# Altium schematic sheet MCU.SchDoc
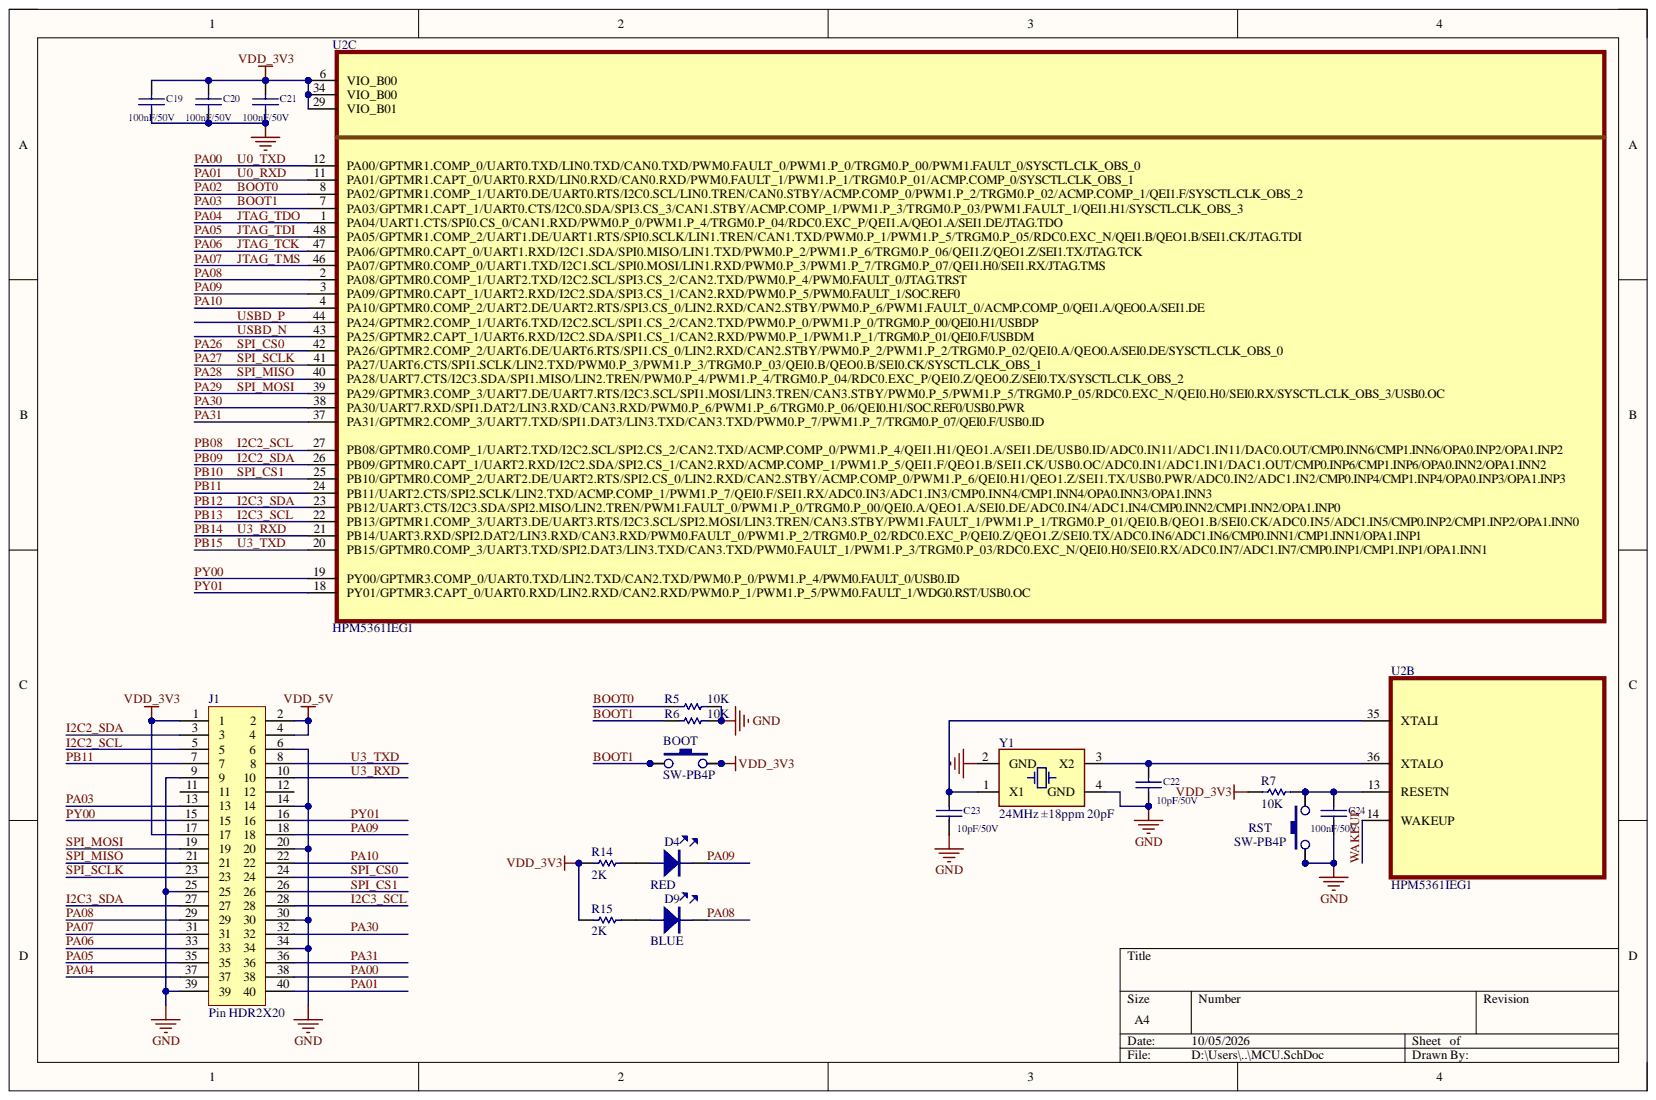
[diagram p0: MCU.SchDoc]

## Parts
BOOT  SW-PB4P  [SMD,3.3x4.2mm]
C19  100nF/50V  [C0402]
C20  100nF/50V  [C0402]
C21  100nF/50V  [C0402]
C22  10pF/50V  [C0402]
C23  10pF/50V  [C0402]
C24  100nF/50V  [C0402]
D4  RED  [LED0402 RED]
D9  BLUE  [LED0402 BLUE]
J1  Pin HDR2X20  [Pin HDR2X20/2.54mm-S]
R5  10K  [R0402]
R6  10K  [R0402]
R7  10K  [R0402]
R14  2K  [R0402]
R15  2K  [R0402]
RST  SW-PB4P  [SMD,3.3x4.2mm]
U2  HPM5361IEG1  [QFN48 6*6]  (parts B,C)
Y1  24MHz ±18ppm 20pF  [OSC 2016-4P]

## Nets
GND: C19.2 C20.2 C21.2 C22.2 C23.2 C24.2 J1.6 J1.9 J1.14 J1.20 J1.25 J1.30 J1.34 J1.39 R5.1 R6.1 RST.3 RST.4 Y1.2(GND) Y1.4(GND)
VDD_3V3: BOOT.3 BOOT.4 C19.1 C20.1 C21.1 J1.1 J1.17 R7.2 R14.2 R15.2 U2.6(VIO_B00) U2.29(VIO_B01) U2.34(VIO_B00)
VDD_5V: J1.2 J1.4
BOOT0: R5.2 U2.8(PA02/GPTMR1.COMP_1/UART0.DE/UART0.RTS/I2C0.SCL/LIN0.TREN/CAN0.STBY/ACMP.COMP_0/PWM1.P_2/TRGM0.P_02/ACMP.COMP_1/QEI1.F/SYSCTL.CLK_OBS_2)
BOOT1: BOOT.1 BOOT.2 J1.13 R6.2 U2.7(PA03/GPTMR1.CAPT_1/UART0.CTS/I2C0.SDA/SPI3.CS_3/CAN1.STBY/ACMP.COMP_1/PWM1.P_3/TRGM0.P_03/PWM1.FAULT_1/QEI1.H1/SYSCTL.CLK_OBS_3)
I2C2_SCL: J1.5 U2.27(PB08/GPTMR0.COMP_1/UART2.TXD/I2C2.SCL/SPI2.CS_2/CAN2.TXD/ACMP.COMP_0/PWM1.P_4/QEI1.H1/QEO1.A/SEI1.DE/USB0.ID/ADC0.IN11/ADC1.IN11/DAC0.OUT/CMP0.INN6/CMP1.INN6/OPA0.INP2/OPA1.INP2)
I2C2_SDA: J1.3 U2.26(PB09/GPTMR0.CAPT_1/UART2.RXD/I2C2.SDA/SPI2.CS_1/CAN2.RXD/ACMP.COMP_1/PWM1.P_5/QEI1.F/QEO1.B/SEI1.CK/USB0.OC/ADC0.IN1/ADC1.IN1/DAC1.OUT/CMP0.INP6/CMP1.INP6/OPA0.INN2/OPA1.INN2)
I2C3_SCL: J1.28 U2.22(PB13/GPTMR1.COMP_3/UART3.DE/UART3.RTS/I2C3.SCL/SPI2.MOSI/LIN3.TREN/CAN3.STBY/PWM1.FAULT_1/PWM1.P_1/TRGM0.P_01/QEI0.B/QEO1.B/SEI0.CK/ADC0.IN5/ADC1.IN5/CMP0.INP2/CMP1.INP2/OPA1.INN0)
I2C3_SDA: J1.27 U2.23(PB12/UART3.CTS/I2C3.SDA/SPI2.MISO/LIN2.TREN/PWM1.FAULT_0/PWM1.P_0/TRGM0.P_00/QEI0.A/QEO1.A/SEI0.DE/ADC0.IN4/ADC1.IN4/CMP0.INN2/CMP1.INN2/OPA1.INP0)
JTAG_TCK: J1.33 U2.47(PA06/GPTMR0.CAPT_0/UART1.RXD/I2C1.SDA/SPI0.MISO/LIN1.TXD/PWM0.P_2/PWM1.P_6/TRGM0.P_06/QEI1.Z/QEO1.Z/SEI1.TX/JTAG.TCK)
JTAG_TDI: J1.35 U2.48(PA05/GPTMR1.COMP_2/UART1.DE/UART1.RTS/SPI0.SCLK/LIN1.TREN/CAN1.TXD/PWM0.P_1/PWM1.P_5/TRGM0.P_05/RDC0.EXC_N/QEI1.B/QEO1.B/SEI1.CK/JTAG.TDI)
JTAG_TDO: J1.37 U2.1(PA04/UART1.CTS/SPI0.CS_0/CAN1.RXD/PWM0.P_0/PWM1.P_4/TRGM0.P_04/RDC0.EXC_P/QEI1.A/QEO1.A/SEI1.DE/JTAG.TDO)
JTAG_TMS: J1.31 U2.46(PA07/GPTMR0.COMP_0/UART1.TXD/I2C1.SCL/SPI0.MOSI/LIN1.RXD/PWM0.P_3/PWM1.P_7/TRGM0.P_07/QEI1.H0/SEI1.RX/JTAG.TMS)
PA00: J1.38 U2.12(PA00/GPTMR1.COMP_0/UART0.TXD/LIN0.TXD/CAN0.TXD/PWM0.FAULT_0/PWM1.P_0/TRGM0.P_00/PWM1.FAULT_0/SYSCTL.CLK_OBS_0)
PA01: J1.40 U2.11(PA01/GPTMR1.CAPT_0/UART0.RXD/LIN0.RXD/CAN0.RXD/PWM0.FAULT_1/PWM1.P_1/TRGM0.P_01/ACMP.COMP_0/SYSCTL.CLK_OBS_1)
PA08: D9.K J1.29 U2.2(PA08/GPTMR0.COMP_1/UART2.TXD/I2C2.SCL/SPI3.CS_2/CAN2.TXD/PWM0.P_4/PWM0.FAULT_0/JTAG.TRST)
PA09: D4.K J1.18 U2.3(PA09/GPTMR0.CAPT_1/UART2.RXD/I2C2.SDA/SPI3.CS_1/CAN2.RXD/PWM0.P_5/PWM0.FAULT_1/SOC.REF0)
PA10: J1.22 U2.4(PA10/GPTMR0.COMP_2/UART2.DE/UART2.RTS/SPI3.CS_0/LIN2.RXD/CAN2.STBY/PWM0.P_6/PWM1.FAULT_0/ACMP.COMP_0/QEI1.A/QEO0.A/SEI1.DE)
PA26: J1.24 U2.42(PA26/GPTMR2.COMP_2/UART6.DE/UART6.RTS/SPI1.CS_0/LIN2.RXD/CAN2.STBY/PWM0.P_2/PWM1.P_2/TRGM0.P_02/QEI0.A/QEO0.A/SEI0.DE/SYSCTL.CLK_OBS_0)
PA27: J1.23 U2.41(PA27/UART6.CTS/SPI1.SCLK/LIN2.TXD/PWM0.P_3/PWM1.P_3/TRGM0.P_03/QEI0.B/QEO0.B/SEI0.CK/SYSCTL.CLK_OBS_1)
PA28: J1.21 U2.40(PA28/UART7.CTS/I2C3.SDA/SPI1.MISO/LIN2.TREN/PWM0.P_4/PWM1.P_4/TRGM0.P_04/RDC0.EXC_P/QEI0.Z/QEO0.Z/SEI0.TX/SYSCTL.CLK_OBS_2)
PA29: J1.19 U2.39(PA29/GPTMR3.COMP_3/UART7.DE/UART7.RTS/I2C3.SCL/SPI1.MOSI/LIN3.TREN/CAN3.STBY/PWM0.P_5/PWM1.P_5/TRGM0.P_05/RDC0.EXC_N/QEI0.H0/SEI0.RX/SYSCTL.CLK_OBS_3/USB0.OC)
PA30: J1.32 U2.38(PA30/UART7.RXD/SPI1.DAT2/LIN3.RXD/CAN3.RXD/PWM0.P_6/PWM1.P_6/TRGM0.P_06/QEI0.H1/SOC.REF0/USB0.PWR)
PA31: J1.36 U2.37(PA31/GPTMR2.COMP_3/UART7.TXD/SPI1.DAT3/LIN3.TXD/CAN3.TXD/PWM0.P_7/PWM1.P_7/TRGM0.P_07/QEI0.F/USB0.ID)
PB10: J1.26 U2.25(PB10/GPTMR0.COMP_2/UART2.DE/UART2.RTS/SPI2.CS_0/LIN2.RXD/CAN2.STBY/ACMP.COMP_0/PWM1.P_6/QEI0.H1/QEO1.Z/SEI1.TX/USB0.PWR/ADC0.IN2/ADC1.IN2/CMP0.INP4/CMP1.INP4/OPA0.INP3/OPA1.INP3)
PB11: J1.7 U2.24(PB11/UART2.CTS/SPI2.SCLK/LIN2.TXD/ACMP.COMP_1/PWM1.P_7/QEI0.F/SEI1.RX/ADC0.IN3/ADC1.IN3/CMP0.INN4/CMP1.INN4/OPA0.INN3/OPA1.INN3)
PB14: J1.10 U2.21(PB14/UART3.RXD/SPI2.DAT2/LIN3.RXD/CAN3.RXD/PWM0.FAULT_0/PWM1.P_2/TRGM0.P_02/RDC0.EXC_P/QEI0.Z/QEO1.Z/SEI0.TX/ADC0.IN6/ADC1.IN6/CMP0.INN1/CMP1.INN1/OPA1.INP1)
PB15: J1.8 U2.20(PB15/GPTMR0.COMP_3/UART3.TXD/SPI2.DAT3/LIN3.TXD/CAN3.TXD/PWM0.FAULT_1/PWM1.P_3/TRGM0.P_03/RDC0.EXC_N/QEI0.H0/SEI0.RX/ADC0.IN7/ADC1.IN7/CMP0.INP1/CMP1.INP1/OPA1.INN1)
PY00: J1.15 U2.19(PY00/GPTMR3.COMP_0/UART0.TXD/LIN2.TXD/CAN2.TXD/PWM0.P_0/PWM1.P_4/PWM0.FAULT_0/USB0.ID)
PY01: J1.16 U2.18(PY01/GPTMR3.CAPT_0/UART0.RXD/LIN2.RXD/CAN2.RXD/PWM0.P_1/PWM1.P_5/PWM0.FAULT_1/WDG0.RST/USB0.OC)
USBD_N: U2.43(PA25/GPTMR2.CAPT_1/UART6.RXD/I2C2.SDA/SPI1.CS_1/CAN2.RXD/PWM0.P_1/PWM1.P_1/TRGM0.P_01/QEI0.F/USBDM)
USBD_P: U2.44(PA24/GPTMR2.COMP_1/UART6.TXD/I2C2.SCL/SPI1.CS_2/CAN2.TXD/PWM0.P_0/PWM1.P_0/TRGM0.P_00/QEI0.H1/USBDP)
WAKEUP: U2.14(WAKEUP)
NetC22_1: C22.1 U2.36(XTALO) Y1.3(X2)
NetC23_1: C23.1 U2.35(XTALI) Y1.1(X1)
NetC24_1: C24.1 R7.1 RST.1 RST.2 U2.13(RESETN)
NetD4_A: D4.A R14.1
NetD9_A: D9.A R15.1

Not connected: J1 11 12

## Library data of the parts
BOOT RST = SW-PB4P: Manufacturer: DEALON(德艺隆); JCL-Code: C2905184; Name: Switch; PreferredModel: TD-1221SR-2.5H-250; WeldingType: SMD; Component Kind: Standard; Description: SW-PB4P; Library Name: My_Integrated_Library.SchLib
C19-C21 C24 = Cap: Capacitor; Manufacturer: SAMSUNG(三星); JCL-Code: C307331; Name: Capacitance; PreferredModel: CL05B104KB54PNC; WeldingType: SMD; Component Kind: Standard; Library Name: My_Integrated_Library.SchLib
C22 C23 = Cap: Capacitor; Manufacturer: muRata(村田); JCL-Code: C161520; Name: Capacitance; PreferredModel: GRM1555C1H100FA01D; WeldingType: SMD; Component Kind: Standard; Library Name: My_Integrated_Library.SchLib
D4 = LED: Manufacturer: 国星光电; JCL-Code: C130719; PreferredModel: NCD0402R1; WeldingType: SMD; Component Kind: Standard; Description: LED; Name: LED; Library Name: SCH_LIB_CJH.SchLib
D9 = LED: Manufacturer: 国星光电; JCL-Code: C130724; PreferredModel: NCD0402B1; WeldingType: SMD; Component Kind: Standard; Description: LED; Name: LED; Library Name: SCH_LIB_CJH.SchLib
R5-R7 = Res: Resistance; Manufacturer: YAGEO; InternalNumbering: GRD1049; JCL-Code: C144807; PreferredModel: AC0402FR-0710KL; WeldingType: SMD; Component Kind: Standard; Library Name: My_Integrated_Library.SchLib; Name: Resistance
R14 R15 = Res: Resistance; Manufacturer: UNI-ROYAL(厚声); JCL-Code: C4109; PreferredModel: 0402WGF2001TCE; WeldingType: SMD; Component Kind: Standard; Library Name: My_Integrated_Library.SchLib; Name: Resistance
U2 = HPM5361IEG1: 32 bit RISC-V MCU; Manufacturer: HPMICRO Semiconductor; WeldingType: SMD; Component Kind: Standard; Name: 32 bit RISC-V MCU; PreferredModel: HPM5361IEG1; Library Name: HPM5301_DAPLINK.SCHLIB
Y1 = XTAL_4P: Manufacturer: YXC(扬兴晶振); JCL-Code: C22434877; Name: Crystal oscillator; PreferredModel: XL7EL89COI-111YLC-24M; WeldingType: SMD; Component Kind: Standard; Library Name: My_Integrated_Library.SchLib
J1 = Pin HDR2X20: Component Kind: Standard; Library Name: My_Integrated_Library.SchLib
